# Altium schematic sheet 1.WIZ750SR-110_W7500.SchDoc
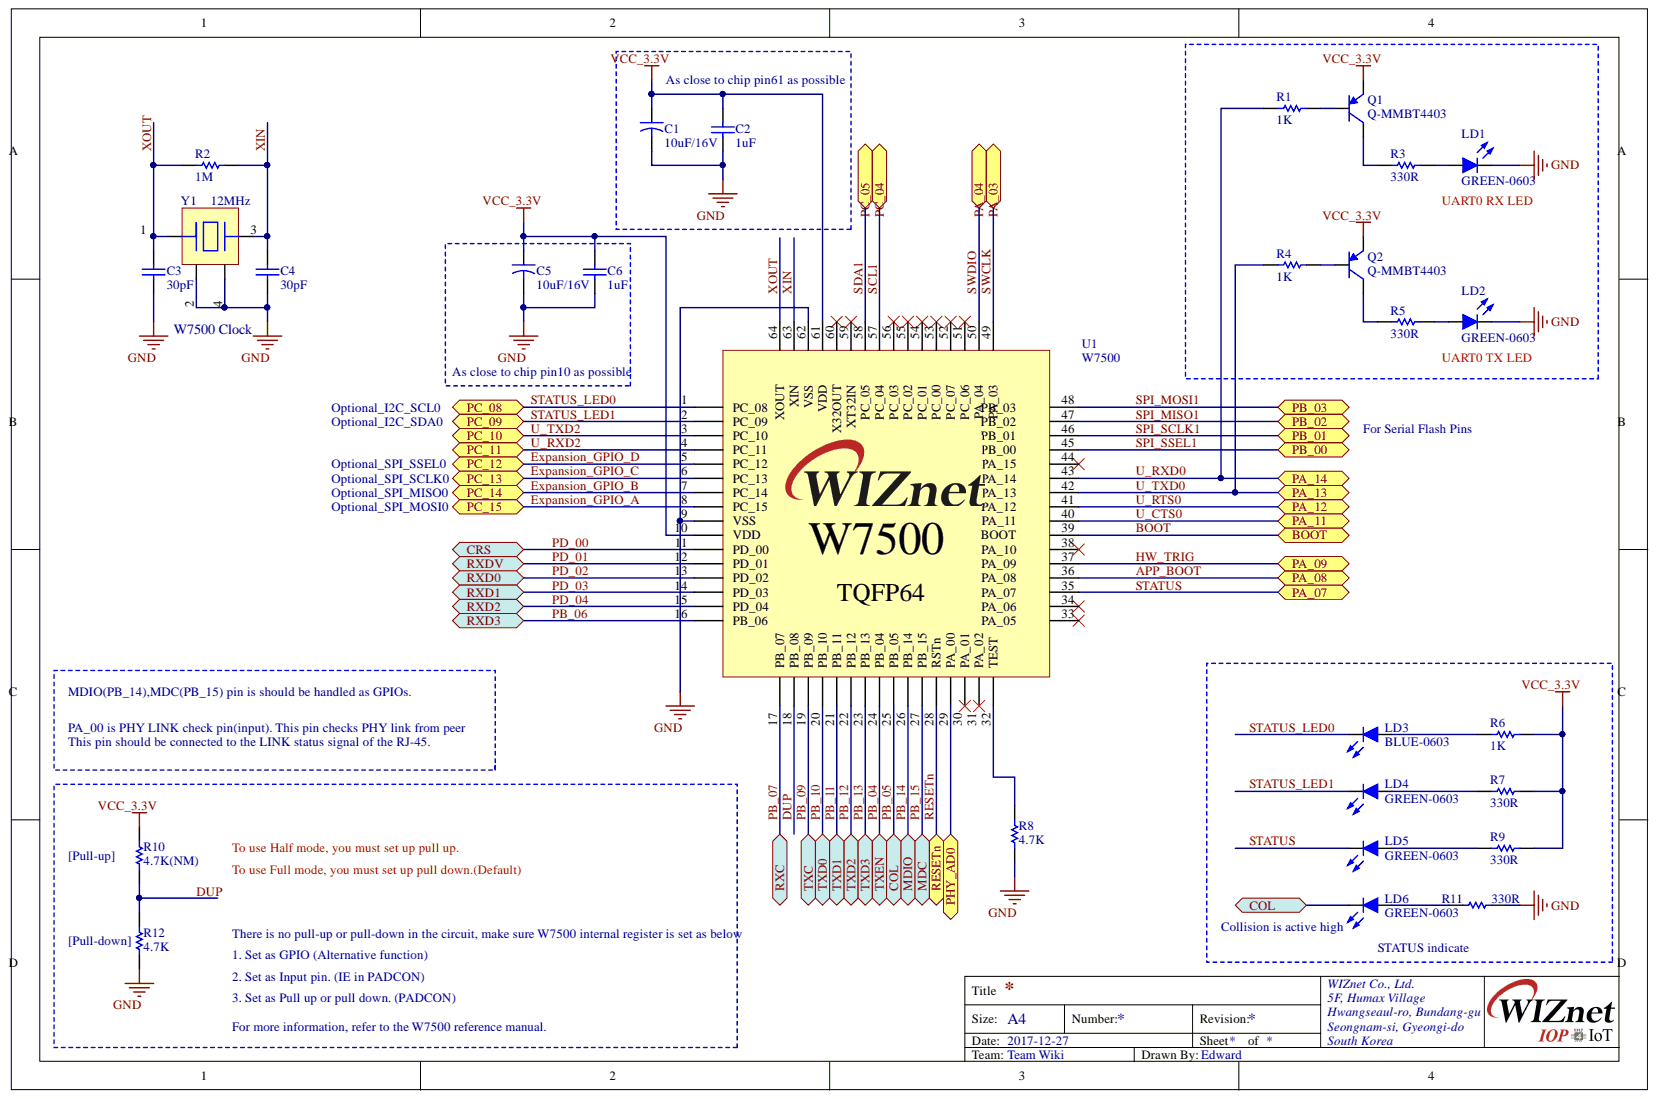
[diagram p0: 1.WIZ750SR-110_W7500.SchDoc]

## Parts
C1  10uF/16V  [CAP-A Size]
C2  1uF  [CAP-0603]
C3  30pF  [CAP-0603]
C4  30pF  [CAP-0603]
C5  10uF/16V  [CAP-A Size]
C6  1uF  [CAP-0603]
LD1  GREEN-0603  [LED-0603-GREEN]
LD2  GREEN-0603  [LED-0603-GREEN]
LD3  BLUE-0603  [LED-0603-BLUE]
LD4  GREEN-0603  [LED-0603-GREEN]
LD5  GREEN-0603  [LED-0603-GREEN]
LD6  GREEN-0603  [LED-0603-GREEN]
Q1  Q-MMBT4403  [SOT-23-3L]
Q2  Q-MMBT4403  [SOT-23-3L]
R1  1K  [RES-0603]
R2  1M  [RES-0603]
R3  330R  [RES-0603]
R4  1K  [RES-0603]
R5  330R  [RES-0603]
R6  1K  [RES-0603]
R7  330R  [RES-0603]
R8  4.7K  [RES-0603]
R9  330R  [RES-0603]
R10  4.7K(NM)  [RES-0603]
R11  330R  [RES-0603]
R12  4.7K  [RES-0603]
U1  W7500  [W7500-LQFP64]
Y1  12MHz  [OSC-TZ1067B]

## Ports
BOOT, COL, CRS, MDC, MDIO, PA_03, PA_04, PA_07, PA_08, PA_09, PA_11, PA_12, PA_13, PA_14, PB_00, PB_01, PB_02, PB_03, PC_04, PC_05, PC_08, PC_09, PC_10, PC_11, PC_12, PC_13, PC_14, PC_15, PHY_AD0, RESETN, RXC, RXD0, RXD1, RXD2, RXD3, RXDV, TXC, TXD0, TXD1, TXD2, TXD3, TXEN

## Nets
GND: C1.2 C2.1 C3.1 C4.1 C5.2 C6.1 LD1.2 LD2.2 R8.1 R11.2 R12.1 U1.9(VSS) U1.62 Y1.2 Y1.4
VCC_3.3V: C1.1 C2.2 C5.1 C6.2 Q1.2 Q2.2 R6.2 R7.2 R9.2 R10.2 U1.10(VDD) U1.61
APP_BOOT: U1.36 ; port PA_08
BOOT: U1.39 ; port BOOT
DUP: R10.1 R12.2 U1.18(PB_08)
EXPANSION_GPIO_A: U1.8(PC_15) ; port PC_15
EXPANSION_GPIO_B: U1.7(PC_14) ; port PC_14
EXPANSION_GPIO_C: U1.6(PC_13) ; port PC_13
EXPANSION_GPIO_D: U1.5(PC_12) ; port PC_12
HW_TRIG: U1.37 ; port PA_09
PB_04: U1.24(PB_04) ; port TXEN
PB_05: LD6.2 U1.25(PB_05) ; port COL
PB_06: U1.16(PB_06) ; port RXD3
PB_07: U1.17(PB_07) ; port RXC
PB_09: U1.19(PB_09) ; port TXC
PB_10: U1.20(PB_10) ; port TXD0
PB_11: U1.21(PB_11) ; port TXD1
PB_12: U1.22(PB_12) ; port TXD2
PB_13: U1.23(PB_13) ; port TXD3
PB_14: U1.26(PB_14) ; port MDIO
PB_15: U1.27(PB_15) ; port MDC
PD_00: U1.11(PD_00) ; port CRS
PD_01: U1.12(PD_01) ; port RXDV
PD_02: U1.13(PD_02) ; port RXD0
PD_03: U1.14(PD_03) ; port RXD1
PD_04: U1.15(PD_04) ; port RXD2
PHY_AD0: U1.29(PA_00) ; port PHY_AD0
RESETN: U1.28(RSTn) ; port RESETN
SCL1: U1.57 ; port PC_04
SDA1: U1.58 ; port PC_05
SPI_MISO1: U1.47 ; port PB_02
SPI_MOSI1: U1.48 ; port PB_03
SPI_SCLK1: U1.46 ; port PB_01
SPI_SSEL1: U1.45 ; port PB_00
STATUS: LD5.2 U1.35 ; port PA_07
STATUS_LED0: LD3.2 U1.1(PC_08) ; port PC_08
STATUS_LED1: LD4.2 U1.2(PC_09) ; port PC_09
SWCLK: U1.49 ; port PA_03
SWDIO: U1.50 ; port PA_04
U_CTS0: U1.40 ; port PA_11
U_RTS0: U1.41 ; port PA_12
U_RXD0: R1.2 U1.43 ; port PA_14
U_RXD2: U1.4(PC_11) ; port PC_11
U_TXD0: R4.2 U1.42 ; port PA_13
U_TXD2: U1.3(PC_10) ; port PC_10
XIN: C4.2 R2.2 U1.63 Y1.3
XOUT: C3.2 R2.1 U1.64 Y1.1
NetLD1_1: LD1.1 R3.1
NetLD2_1: LD2.1 R5.2
NetLD3_1: LD3.1 R6.1
NetLD4_1: LD4.1 R7.1
NetLD5_1: LD5.1 R9.1
NetLD6_1: LD6.1 R11.1
NetQ1_1: Q1.1 R1.1
NetQ1_3: Q1.3 R3.2
NetQ2_1: Q2.1 R4.1
NetQ2_3: Q2.3 R5.1
NetR8_2: R8.2 U1.32(TEST)

Not connected: U1 30(PA_01) 31(PA_02) 33 34 38 44 51 52 53 54 55 56 59 60

## Library data of the parts
C1 C5 = CAP-A Size: Tantla CAP A Size EIA/IECQ 3216; Component Kind: Standard; Library Name: Scott_lib.IntLib
C2-C4 C6 = CAP-0603: Ceramic CAP 0603(1608); Component Kind: Standard; Library Name: Scott_lib.IntLib
LD1-LD6 = LED-0603: Component Kind: Standard; Description: LED-0603; Library Name: Edward.IntLib
Q1 Q2 = Q-MMBT4403: PNP General-Purpose Amplifier; Component Kind: Standard; Library Name: Scott_lib.IntLib
R1-R12 = RES-0603: Component Kind: Standard; Description: RES-0603; Library Name: Scott_lib.IntLib
U1 = W7500: WIZnet ARM Cortex-M0; Component Kind: Standard; Library Name: Scott_lib.IntLib
Y1 = OSC-TZ1067B-12MHz: X-tal 12MHz,3.2x2.5 SMD, CL=20pF; Component Kind: Standard; Library Name: Scott_lib.IntLib
